# Altium schematic sheet Boot Mode Selection & JTAG.SchDoc
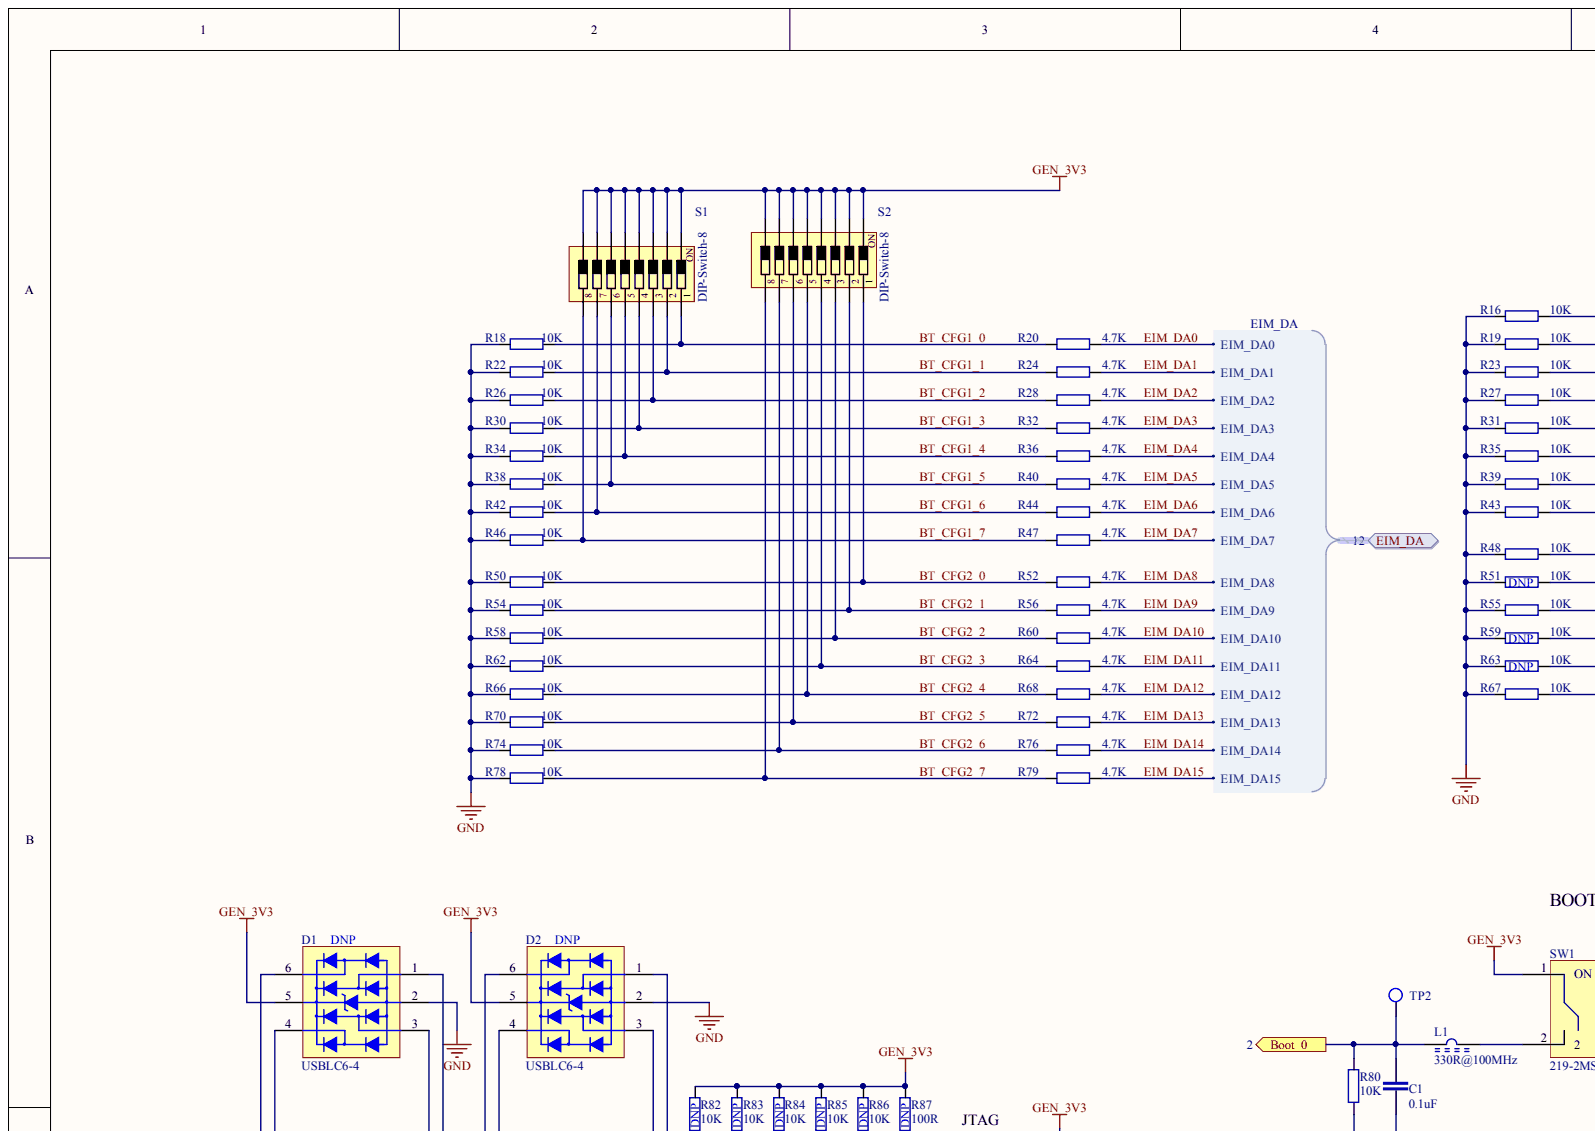
[diagram p0: Boot Mode Selection & JTAG.SchDoc, top left]
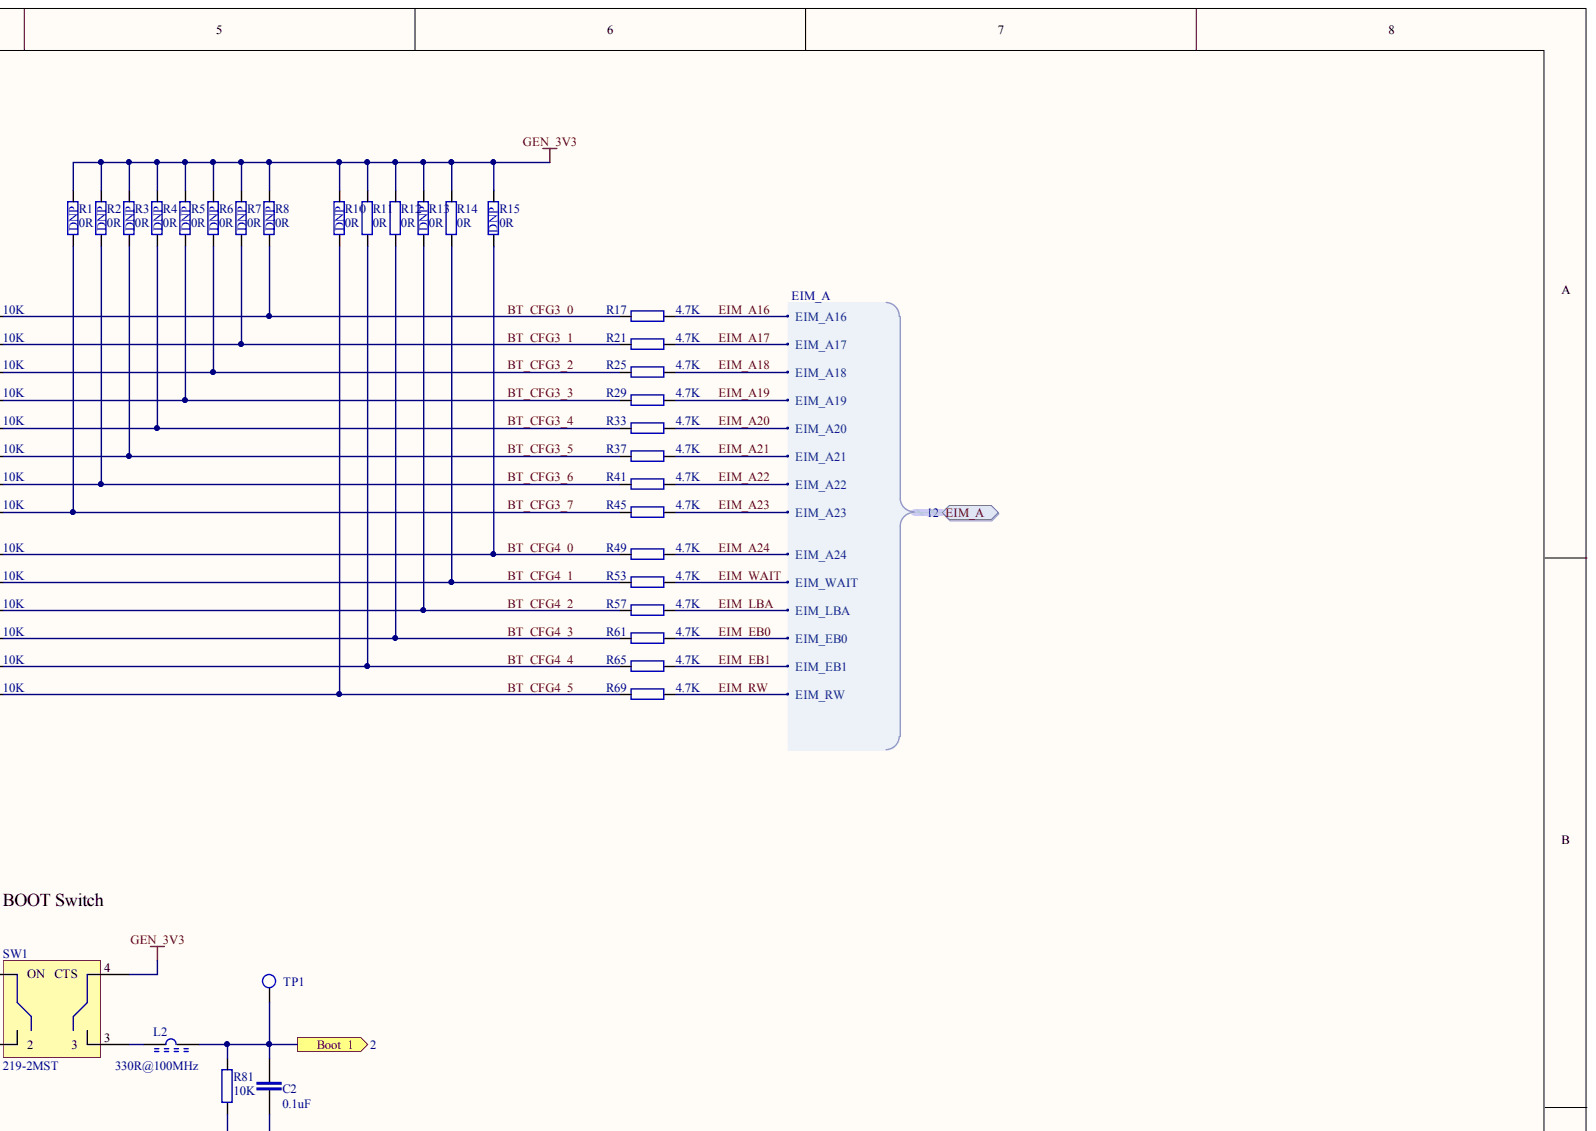
[diagram p1: Boot Mode Selection & JTAG.SchDoc, top right]
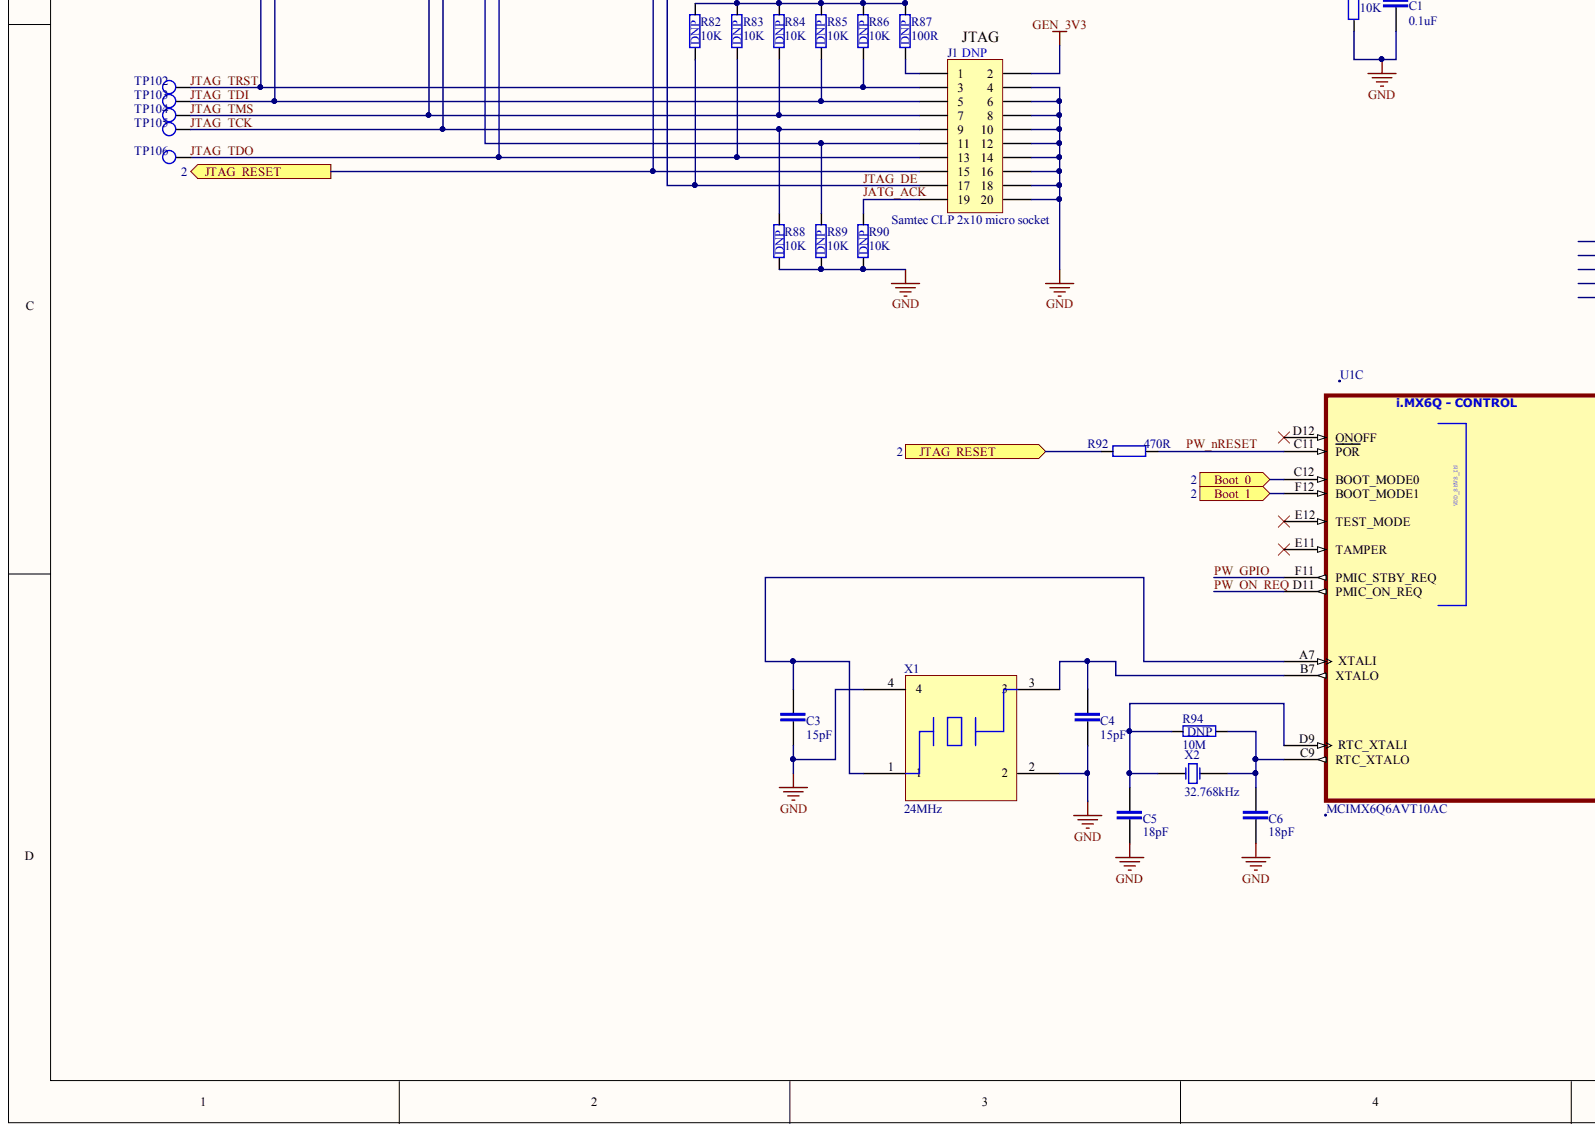
[diagram p2: Boot Mode Selection & JTAG.SchDoc, bottom left]
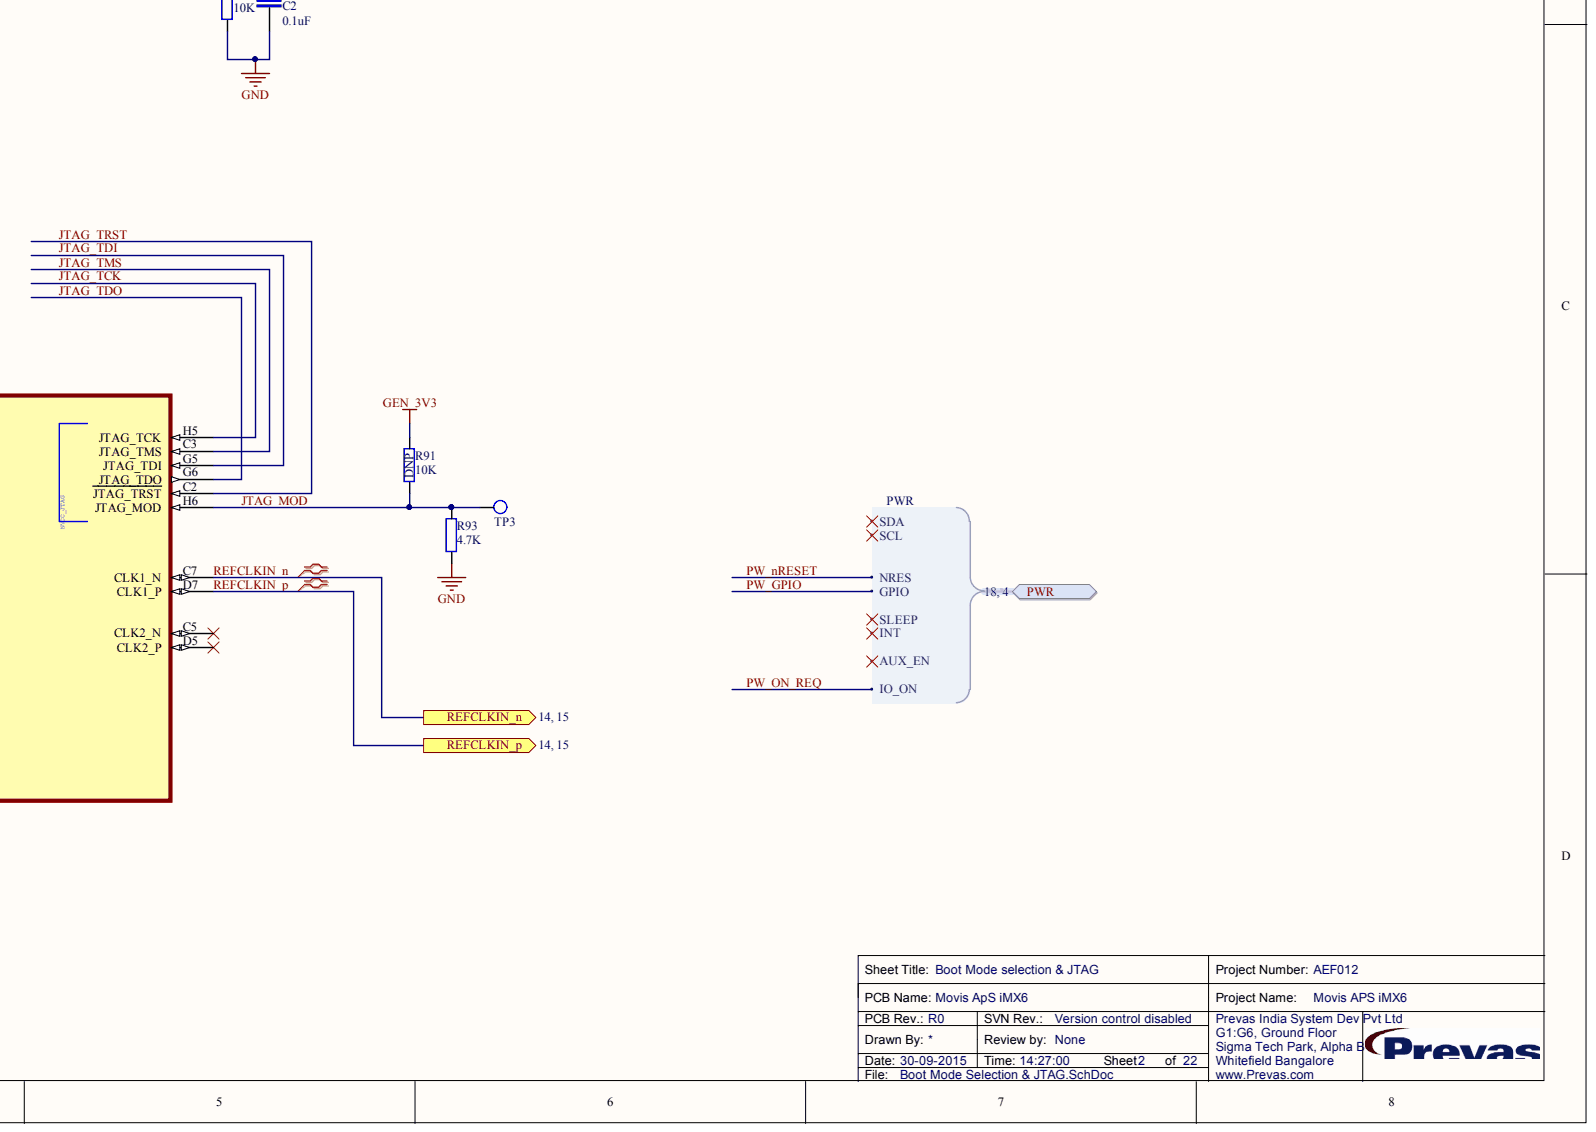
[diagram p3: Boot Mode Selection & JTAG.SchDoc, bottom right]

## Parts
C1  0.1uF  [CAPC1005X55N]
C2  0.1uF  [CAPC1005X55N]
C3  15pF  [CAPC1005X55N]
C4  15pF  [CAPC1005X55N]
C5  18pF  [CAPC1005X55N]
C6  18pF  [CAPC1005X55N]
D1  USBLC6-4  Fitted: DNP  [SOT23-6L]
D2  USBLC6-4  Fitted: DNP  [SOT23-6L]
J1  Samtec CLP 2x10 micro socket  Fitted: DNP  source_manufacturer_1: Samtec  [CLP-110-02-L-D-P(Pins Swop)]
L1  330R@100MHz  [INDC1608X95N]
L2  330R@100MHz  [INDC1608X95N]
R1  0R  Fitted: DNP  [RESC1608X55N]
R2  0R  Fitted: DNP  [RESC1608X55N]
R3  0R  Fitted: DNP  [RESC1608X55N]
R4  0R  Fitted: DNP  [RESC1608X55N]
R5  0R  Fitted: DNP  [RESC1608X55N]
R6  0R  Fitted: DNP  [RESC1608X55N]
R7  0R  Fitted: DNP  [RESC1608X55N]
R8  0R  Fitted: DNP  [RESC1608X55N]
R10  0R  Fitted: DNP  [RESC1608X55N]
R11  0R  [RESC1608X55N]
R12  0R  [RESC1608X55N]
R13  0R  Fitted: DNP  [RESC1608X55N]
R14  0R  [RESC1608X55N]
R15  0R  Fitted: DNP  [RESC1608X55N]
R16  10K  [RESC1608X55N]
R17  4.7K  [RESC1608X55N]
R18  10K  [RESC1608X55N]
R19  10K  [RESC1608X55N]
R20  4.7K  [RESC1608X55N]
R21  4.7K  [RESC1608X55N]
R22  10K  [RESC1608X55N]
R23  10K  [RESC1608X55N]
R24  4.7K  [RESC1608X55N]
R25  4.7K  [RESC1608X55N]
R26  10K  [RESC1608X55N]
R27  10K  [RESC1608X55N]
R28  4.7K  [RESC1608X55N]
R29  4.7K  [RESC1608X55N]
R30  10K  [RESC1608X55N]
R31  10K  [RESC1608X55N]
R32  4.7K  [RESC1608X55N]
R33  4.7K  [RESC1608X55N]
R34  10K  [RESC1608X55N]
R35  10K  [RESC1608X55N]
R36  4.7K  [RESC1608X55N]
R37  4.7K  [RESC1608X55N]
R38  10K  [RESC1608X55N]
R39  10K  [RESC1608X55N]
R40  4.7K  [RESC1608X55N]
R41  4.7K  [RESC1608X55N]
R42  10K  [RESC1608X55N]
R43  10K  [RESC1608X55N]
R44  4.7K  [RESC1608X55N]
R45  4.7K  [RESC1608X55N]
R46  10K  [RESC1608X55N]
R47  4.7K  [RESC1608X55N]
R48  10K  [RESC1608X55N]
R49  4.7K  [RESC1608X55N]
R50  10K  [RESC1608X55N]
R51  10K  Fitted: DNP  [RESC1608X55N]
R52  4.7K  [RESC1608X55N]
R53  4.7K  [RESC1608X55N]
R54  10K  [RESC1608X55N]
R55  10K  [RESC1608X55N]
R56  4.7K  [RESC1608X55N]
R57  4.7K  [RESC1608X55N]
R58  10K  [RESC1608X55N]
R59  10K  Fitted: DNP  [RESC1608X55N]
R60  4.7K  [RESC1608X55N]
R61  4.7K  [RESC1608X55N]
R62  10K  [RESC1608X55N]
R63  10K  Fitted: DNP  [RESC1608X55N]
R64  4.7K  [RESC1608X55N]
R65  4.7K  [RESC1608X55N]
R66  10K  [RESC1608X55N]
R67  10K  [RESC1608X55N]
R68  4.7K  [RESC1608X55N]
R69  4.7K  [RESC1608X55N]
R70  10K  [RESC1608X55N]
R72  4.7K  [RESC1608X55N]
R74  10K  [RESC1608X55N]
R76  4.7K  [RESC1608X55N]
R78  10K  [RESC1608X55N]
R79  4.7K  [RESC1608X55N]
R80  10K  [RESC1608X55N]
R81  10K  [RESC1608X55N]
R82  10K  Fitted: DNP  [RESC1608X55N]
R83  10K  Fitted: DNP  [RESC1608X55N]
R84  10K  Fitted: DNP  [RESC1608X55N]
R85  10K  Fitted: DNP  [RESC1608X55N]
R86  10K  [RESC1608X55N]
R87  100R  Fitted: DNP  [RESC1608X55N]
R88  10K  Fitted: DNP  [RESC1608X55N]
R89  10K  Fitted: DNP  [RESC1608X55N]
R90  10K  Fitted: DNP  [RESC1608X55N]
R91  10K  Fitted: DNP  [RESC1608X55N]
R92  470R  [RESC1608X55N]
R93  4.7K  [RESC1608X55N]
R94  10M  Fitted: DNP  [RESC1608X55N]
S1  DIP-Switch-8  [SW_DHN-08F-T-V]
S2  DIP-Switch-8  [SW_DHN-08F-T-V]
SW1  219-2MST  [SW_219-2MST]
TP1  Testpoint  [TP_SMD]  (value not drawn)  (no BOM)
TP2  Testpoint  [TP_SMD]  (value not drawn)  (no BOM)
TP3  Testpoint  [TP_SMD]  (value not drawn)  (no BOM)
TP102  Testpoint  [TP_SMD]  (value not drawn)  (no BOM)
TP103  Testpoint  [TP_SMD]  (value not drawn)  (no BOM)
TP104  Testpoint  [TP_SMD]  (value not drawn)  (no BOM)
TP105  Testpoint  [TP_SMD]  (value not drawn)  (no BOM)
TP106  Testpoint  [TP_SMD]  (value not drawn)  (no BOM)
U1  MCIMX6Q6AVT10AC  [BGA624C80P25X25_2100X2100X160]  (part C)
X1  24MHz  [OSCCC240X390X80-4N]
X2  32.768kHz  [OSC_AB26TRB-32.768kHz]

## Ports
BOOT_0, BOOT_1, EIM_A, EIM_A.EIM_A16, EIM_A.EIM_A17, EIM_A.EIM_A18, EIM_A.EIM_A19, EIM_A.EIM_A20, EIM_A.EIM_A21, EIM_A.EIM_A22, EIM_A.EIM_A23, EIM_A.EIM_A24, EIM_A.EIM_EB0, EIM_A.EIM_EB1, EIM_A.EIM_LBA, EIM_A.EIM_RW, EIM_A.EIM_WAIT, EIM_DA, EIM_DA.EIM_DA0, EIM_DA.EIM_DA1, EIM_DA.EIM_DA2, EIM_DA.EIM_DA3, EIM_DA.EIM_DA4, EIM_DA.EIM_DA5, EIM_DA.EIM_DA6, EIM_DA.EIM_DA7, EIM_DA.EIM_DA8, EIM_DA.EIM_DA9, EIM_DA.EIM_DA10, EIM_DA.EIM_DA11, EIM_DA.EIM_DA12, EIM_DA.EIM_DA13, EIM_DA.EIM_DA14, EIM_DA.EIM_DA15, JTAG_RESET, PWR, PWR.AUX_EN, PWR.GPIO, PWR.INT, PWR.IO_ON, PWR.NRES, PWR.SCL, PWR.SDA, PWR.SLEEP, REFCLKIN_N, REFCLKIN_P

## Nets
GEN_3V3: D1.5 D2.5 J1.2 R1.2 R2.2 R3.2 R4.2 R5.2 R6.2 R7.2 R8.2 R10.2 R11.2 R12.2 R13.2 R14.2 R15.2 R82.1 R83.1 R84.1 R85.1 R86.1 R87.2 R91.2 S1.9 S1.10 S1.11 S1.12 S1.13 S1.14 S1.15 S1.16 S2.9 S2.10 S2.11 S2.12 S2.13 S2.14 S2.15 S2.16 SW1.1(ON) SW1.4(CTS)
GND: C1.1 C2.1 C3.1 C4.1 C5.1 C6.1 D1.2 D2.2 J1.4 J1.6 J1.8 J1.10 J1.12 J1.14 J1.16 J1.18 J1.20 R16.1 R18.1 R19.1 R22.1 R23.1 R26.1 R27.1 R30.1 R31.1 R34.1 R35.1 R38.1 R39.1 R42.1 R43.1 R46.1 R48.1 R50.1 R51.1 R54.1 R55.1 R58.1 R59.1 R62.1 R63.1 R66.1 R67.1 R70.1 R74.1 R78.1 R80.2 R81.2 R88.2 R89.2 R90.2 R93.2 X1.2 X1.4
BT_CFG1_0: R18.2 R20.1 S1.1
BT_CFG1_1: R22.2 R24.1 S1.2
BT_CFG1_2: R26.2 R28.1 S1.3
BT_CFG1_3: R30.2 R32.1 S1.4
BT_CFG1_4: R34.2 R36.1 S1.5
BT_CFG1_5: R38.2 R40.1 S1.6
BT_CFG1_6: R42.2 R44.1 S1.7
BT_CFG1_7: R46.2 R47.1 S1.8
BT_CFG2_0: R50.2 R52.1 S2.1
BT_CFG2_1: R54.2 R56.1 S2.2
BT_CFG2_2: R58.2 R60.1 S2.3
BT_CFG2_3: R62.2 R64.1 S2.4
BT_CFG2_4: R66.2 R68.1 S2.5
BT_CFG2_5: R70.2 R72.1 S2.6
BT_CFG2_6: R74.2 R76.1 S2.7
BT_CFG2_7: R78.2 R79.1 S2.8
BT_CFG3_0: R8.1 R16.2 R17.1
BT_CFG3_1: R7.1 R19.2 R21.1
BT_CFG3_2: R6.1 R23.2 R25.1
BT_CFG3_3: R5.1 R27.2 R29.1
BT_CFG3_4: R4.1 R31.2 R33.1
BT_CFG3_5: R3.1 R35.2 R37.1
BT_CFG3_6: R2.1 R39.2 R41.1
BT_CFG3_7: R1.1 R43.2 R45.1
BT_CFG4_0: R15.1 R48.2 R49.1
BT_CFG4_1: R14.1 R51.2 R53.1
BT_CFG4_2: R13.1 R55.2 R57.1
BT_CFG4_3: R12.1 R59.2 R61.1
BT_CFG4_4: R11.1 R63.2 R65.1
BT_CFG4_5: R10.1 R67.2 R69.1
EIM_A16: R17.2 ; port EIM_A
EIM_A17: R21.2 ; port EIM_A
EIM_A18: R25.2 ; port EIM_A
EIM_A19: R29.2 ; port EIM_A
EIM_A20: R33.2 ; port EIM_A
EIM_A21: R37.2 ; port EIM_A
EIM_A22: R41.2 ; port EIM_A
EIM_A23: R45.2 ; port EIM_A
EIM_A24: R49.2 ; port EIM_A
EIM_DA0: R20.2 ; port EIM_DA
EIM_DA1: R24.2 ; port EIM_DA
EIM_DA2: R28.2 ; port EIM_DA
EIM_DA3: R32.2 ; port EIM_DA
EIM_DA4: R36.2 ; port EIM_DA
EIM_DA5: R40.2 ; port EIM_DA
EIM_DA6: R44.2 ; port EIM_DA
EIM_DA7: R47.2 ; port EIM_DA
EIM_DA8: R52.2 ; port EIM_DA
EIM_DA9: R56.2 ; port EIM_DA
EIM_DA10: R60.2 ; port EIM_DA
EIM_DA11: R64.2 ; port EIM_DA
EIM_DA12: R68.2 ; port EIM_DA
EIM_DA13: R72.2 ; port EIM_DA
EIM_DA14: R76.2 ; port EIM_DA
EIM_DA15: R79.2 ; port EIM_DA
EIM_EB0: R61.2 ; port EIM_A
EIM_EB1: R65.2 ; port EIM_A
EIM_LBA: R57.2 ; port EIM_A
EIM_RW: R69.2 ; port EIM_A
EIM_WAIT: R53.2 ; port EIM_A
JATG_ACK: J1.19 R90.1
JTAG_DE: D2.1 J1.17 R82.2
JTAG_MOD: R91.1 R93.1 TP3.1 U1.H6(JTAG_MOD)
JTAG_RESET: D2.3 J1.15 R92.1 ; port JTAG_RESET
JTAG_TCK: D1.1 J1.9 R88.1 TP105.1 U1.H5(JTAG_TCK)
JTAG_TDI: D1.4 J1.5 R85.2 TP103.1 U1.G5(JTAG_TDI)
JTAG_TDO: D2.4 J1.13 R83.2 TP106.1 U1.G6(JTAG_TDO)
JTAG_TMS: D1.3 J1.7 R84.2 TP104.1 U1.C3(JTAG_TMS)
JTAG_TRST: D1.6 J1.3 R86.2 TP102.1 U1.C2(JTAG_TRST)
PW_GPIO: U1.F11(PMIC_STBY_REQ) ; port PWR
PW_NRESET: R92.2 U1.C11(POR) ; port PWR
PW_ON_REQ: U1.D11(PMIC_ON_REQ) ; port PWR
REFCLKIN_N: U1.C7(CLK1_N) ; port REFCLKIN_N
REFCLKIN_P: U1.D7(CLK1_P) ; port REFCLKIN_P
NetC1_2: C1.2 L1.1 R80.1 TP2.1 U1.C12(BOOT_MODE0) ; port BOOT_0
NetC2_2: C2.2 L2.2 R81.1 TP1.1 U1.F12(BOOT_MODE1) ; port BOOT_1
NetC3_2: C3.2 U1.A7(XTALI) X1.1
NetC4_2: C4.2 U1.B7(XTALO) X1.3
NetC5_2: C5.2 R94.1 U1.D9(RTC_XTALI) X2.1
NetC6_2: C6.2 R94.2 U1.C9(RTC_XTALO) X2.2
NetD2_6: D2.6 J1.11 R89.1
NetJ1_1: J1.1 R87.1
NetL1_2: L1.2 SW1.2
NetL2_1: L2.1 SW1.3
N00071: ; port PWR
N00072: ; port PWR
N00073: ; port PWR
N00074: ; port PWR
N00075: ; port PWR

Not connected: U1 C5(CLK2_N) D5(CLK2_P) D12(ONOFF) E11(TAMPER) E12(TEST_MODE)

## Library data of the parts
C1 C2 = INNISI-73: CAP CER 0.1UF 50V 10% X5R 0402; ref_part_type: /capacitor/non_polarized/ceramic/; source_manufacturer_1: TDK Corporation; source_manufacturer_1_pn: C1005X5R1H104K050BB; cae_altium_pcb_status: Prototype; Database Table Name: altium_default; db_rev: 7569; elec_temp_range: -55 to +85 C; phy_height: 0.55mm; phy_mounting: SMD; phy_packaging_type: 0402; ref_datasheet: INNISI-71; cae_altium_sch_status: Prototype; Component Kind: Standard; ComponentLink1Description: Datasheet; ComponentLink1URL: https://cop201.prevas.dk/viewvc/datasheet/INNISI-71.pdf?root=hw_components&view=co; db_created: 2015-08-03 03:34:59.0; db_last_updated: 03-08-2015 03:35:01; db_local_id: INNISI-73; db_status: Prototype; elec_tolerance: ±10%; elec_value: 0.1uF; elec_voltage_rating: 50V; phy_material: X5R; ref_keyword: CAP CER 0.1UF 50V 10% X5R 0402; Library Name: altium_mysql.dblib
C3 C4 = INNISI-74: CAP CER 15PF 50V 5% NPO 0402; ref_part_type: /capacitor/non_polarized/ceramic/; source_manufacturer_1: Yageo; source_manufacturer_1_pn: CC0402JRNPO9BN150; cae_altium_pcb_status: Prototype; Database Table Name: altium_default; db_rev: 7570; elec_temp_range: -55 to +125C; phy_height: 0.55mm; phy_material: C0G; phy_mounting: SMD; phy_packaging_type: 0402; ref_datasheet: INNISI-72; ref_keyword: Multilayer chip capacitor; cae_altium_sch_status: Prototype; Component Kind: Standard; ComponentLink1Description: Datasheet; ComponentLink1URL: https://cop201.prevas.dk/viewvc/datasheet/INNISI-72.pdf?root=hw_components&view=co; db_created: 2015-08-03 03:48:01.0; db_last_updated: 03-08-2015 03:48:03; db_local_id: INNISI-74; db_status: Prototype; elec_tolerance: 5%; elec_value: 15pF; elec_voltage_rating: 50V; Library Name: altium_mysql.dblib
C5 C6 = INNISI-75: CAP CER 18PF 50V 5% NPO 0402; ref_part_type: /capacitor/non_polarized/ceramic/; source_manufacturer_1: Yageo; source_manufacturer_1_pn: CC0402JRNPO9BN180; cae_altium_pcb_status: Prototype; Database Table Name: altium_default; db_rev: 7571; elec_temp_range: -55 to +125C; phy_height: 0.55mm; phy_material: C0G; phy_mounting: SMD; phy_packaging_type: 0402; ref_datasheet: INNISI-71; ref_keyword: Ceramic Capacitor 0402; cae_altium_sch_status: Prototype; Component Kind: Standard; ComponentLink1Description: Datasheet; ComponentLink1URL: https://cop201.prevas.dk/viewvc/datasheet/INNISI-71.pdf?root=hw_components&view=co; db_created: 2015-08-03 03:51:37.0; db_last_updated: 03-08-2015 03:51:38; db_local_id: INNISI-75; db_status: Prototype; elec_tolerance: 5%; elec_value: 18pF; elec_voltage_rating: 50V; Library Name: altium_mysql.dblib
D1 D2 = NIMA-155: Dual very low capacitance ESD protection for USB 2.0 up to 480Mb/s; ref_part_type: /diode/transient/; source_manufacturer_1: STMicroelectronics; cae_altium_pcb_status: Prototype; Database Table Name: altium_default; db_rev: 1817; elec_temp_range: -40 to 125; elec_voltage_rating: 5V; phy_height: 1.45mm; phy_mounting: SMD; ref_datasheet: NIMA-115; cae_altium_sch_status: Prototype; Component Kind: Standard; ComponentLink1Description: Datasheet; ComponentLink1URL: https://cop201.prevas.dk/viewvc/datasheet/NIMA-115.pdf?root=hw_components&view=co; db_created: 2009-09-25 21:20:59; db_last_updated: 25-09-2009 21:21:20; db_local_id: NIMA-155; db_status: Prototype; elec_value: USBLC6-4; Mounted: Yes; phy_packaging_type: SOT23-6L; ref_keyword: ESD; source_manufacturer_1_pn: USBLC6-4; Library Name: altium_mysql.dblib
J1 = GEPW-55: ref_part_type: /connector/20 pins/; source_manufacturer_1_pn: CLP-110-02-L-D-P; Database Table Name: altium_default; db_rev: 0; db_status: Prototype; elec_current_rating: 1,75A; elec_temp_range: -55 to 125; phy_height: 2.26mm; phy_mounting: SMD; ref_datasheet: GEPW-43; ref_description: Samtec CLP low profile socket, board-to-board, 50mil pitch, 2x10 pin socket (pins swop); ref_keyword: Samtec board-to-board, CLP; Component Kind: Standard; ComponentLink1Description: Datasheet; ComponentLink1URL: https://cop201/viewvc/datasheet/GEPW-43.pdf?root=hw_components&view=co; db_created: 2009-01-21 14:17:10; db_last_updated: 21-01-2009 14:36:13; db_local_id: GEPW-55; elec_value: Samtec CLP 2x10 micro socket; Library Name: altium_mysql.dblib
L1 L2 = TELA-164: Chip EMIFIL Inductor Type, 330R@100MHz, 0.15R@0Hz; ref_part_type: /inductor/lossy/; source_manufacturer_1: Murata; source_manufacturer_1_pn: BLM18PG331SN1*; cae_altium_pcb_status: Prototype; Database Table Name: altium_default; db_rev: 5543; elec_current_rating: 1.2A; elec_frequency_rating: 100MHz; elec_temp_range: -55 to 125; elec_tolerance: 25%; phy_height: 0.95mm; phy_material: Ferrite; phy_mounting: SMD; phy_packaging_type: 0603; ref_datasheet: SOMO-49; ref_keyword: Ferrite bead 0603; cae_altium_sch_status: Prototype; Component Kind: Standard; ComponentLink1Description: Datasheet; ComponentLink1URL: https://cop201.prevas.dk/viewvc/datasheet/SOMO-49.pdf?root=hw_components&view=co; db_created: 2013-03-14 15:35:31.0; db_last_updated: 14-03-2013 16:11:58; db_local_id: TELA-164; db_status: Prototype; elec_value: 330R@100MHz; Library Name: altium_mysql.dblib
R1-R8 R10-R15 = INVIHA-4: RES SMD 0.0 OHM JUMPER 1/10W; ref_part_type: /resistor/fixed/; source_manufacturer_1: Panasonic Electronic Components; source_manufacturer_1_pn: ERJ-3GEY0R00V; cae_altium_pcb_status: Prototype; Database Table Name: altium_default; db_rev: 7580; elec_power_rating: 0.1W; elec_temp_range: -55 to +155° C; phy_height: 0.55mm; phy_packaging_type: 0603; ref_datasheet: INVIHA-3; ref_keyword: Chip Resistor; cae_altium_sch_status: Prototype; Component Kind: Standard; ComponentLink1Description: Datasheet; ComponentLink1URL: https://cop201.prevas.dk/viewvc/datasheet/INVIHA-3.pdf?root=hw_components&view=co; db_created: 2015-08-03 05:59:19.0; db_last_updated: 03-08-2015 5:59:21 AM; db_local_id: INVIHA-4; db_status: Prototype; elec_value: 0R; phy_mounting: SMD; Library Name: altium_mysql.dblib
R16 R18 R19 R22 R23 R26 R27 R30 R31 R34 R35 R38 R39 R42 R43 R46 R48 R50 R51 R54 R55 R58 R59 R62 R63 R66 R67 R70 R74 R78 R80-R86 R88-R91 = INVIHA-3: RES SMD 10K OHM 1% 1/10W 0603; ref_part_type: /resistor/fixed/; source_manufacturer_1: Yageo; source_manufacturer_1_pn: RC0603FR-0710KL; cae_altium_pcb_status: Prototype; Database Table Name: altium_default; db_rev: 7575; elec_power_rating: 0.1W; elec_temp_range: -55 to +155° C; phy_height: 0.55mm; phy_packaging_type: 0603; ref_datasheet: INVIHA-2; ref_keyword: Chip Resistor; cae_altium_sch_status: Prototype; Component Kind: Standard; ComponentLink1Description: Datasheet; ComponentLink1URL: https://cop201.prevas.dk/viewvc/datasheet/INVIHA-2.pdf?root=hw_components&view=co; db_created: 2015-08-03 05:39:03.0; db_last_updated: 03-08-2015 5:39:05 AM; db_local_id: INVIHA-3; db_status: Prototype; elec_tolerance: 1%; elec_value: 10K; phy_mounting: SMD; Library Name: altium_mysql.dblib
  R80-R86 R88-R90: Mounted: Yes
R17 R20 R21 R24 R25 R28 R29 R32 R33 R36 R37 R40 R41 R44 R45 R47 R49 R52 R53 R56 R57 R60 R61 R64 R65 R68 R69 R72 R76 R79 R93 = INVIHA-5: RES SMD 4.7K OHM 1% 1/10W 0603; ref_part_type: /resistor/fixed/; source_manufacturer_1: Yageo; source_manufacturer_1_pn: RC0603FR-074K7L; cae_altium_pcb_status: Prototype; Database Table Name: altium_default; db_rev: 7581; elec_power_rating: 0.1W; elec_temp_range: -55 to +155° C; phy_height: 0.55mm; phy_packaging_type: 0603; ref_datasheet: INVIHA-4; ref_keyword: Chip Resistor; cae_altium_sch_status: Prototype; Component Kind: Standard; ComponentLink1Description: Datasheet; ComponentLink1URL: https://cop201.prevas.dk/viewvc/datasheet/INVIHA-4.pdf?root=hw_components&view=co; db_created: 2015-08-03 06:09:48.0; db_last_updated: 03-08-2015 6:09:49 AM; db_local_id: INVIHA-5; db_status: Prototype; elec_tolerance: 1%; elec_value: 4.7K; phy_mounting: SMD; Library Name: altium_mysql.dblib
R87 = INVIHA-6: RES SMD 100 OHM 1% 1/10W 0603; ref_part_type: /resistor/fixed/; source_manufacturer_1: Yageo; source_manufacturer_1_pn: RC0603FR-07100RL; cae_altium_pcb_status: Prototype; Database Table Name: altium_default; db_rev: 7583; elec_power_rating: 0.1W; elec_temp_range: -55 to +155° C; phy_height: 0.55mm; phy_packaging_type: 0603; ref_datasheet: INVIHA-2; ref_keyword: Chip Resistor; cae_altium_sch_status: Prototype; Component Kind: Standard; ComponentLink1Description: Datasheet; ComponentLink1URL: https://cop201.prevas.dk/viewvc/datasheet/INVIHA-2.pdf?root=hw_components&view=co; db_created: 2015-08-03 06:19:06.0; db_last_updated: 03-08-2015 6:19:07 AM; db_local_id: INVIHA-6; db_status: Prototype; elec_tolerance: 1%; elec_value: 100R; Mounted: Yes; phy_mounting: SMD; Library Name: altium_mysql.dblib
R92 = INVIHA-7: RES SMD 470 OHM 1% 1/10W 0603; ref_part_type: /resistor/fixed/; source_manufacturer_1: Yageo; source_manufacturer_1_pn: RC0603FR-07470RL; cae_altium_pcb_status: Prototype; Database Table Name: altium_default; db_rev: 7584; elec_power_rating: 0.1W; elec_temp_range: -55 to +155° C; phy_height: 0.55mm; phy_packaging_type: 0603; ref_datasheet: INVIHA-2; ref_keyword: Chip Resistor; cae_altium_sch_status: Prototype; Component Kind: Standard; ComponentLink1Description: Datasheet; ComponentLink1URL: https://cop201.prevas.dk/viewvc/datasheet/INVIHA-2.pdf?root=hw_components&view=co; db_created: 2015-08-03 06:24:05.0; db_last_updated: 03-08-2015 6:24:06 AM; db_local_id: INVIHA-7; db_status: Prototype; elec_tolerance: 1%; elec_value: 470R; phy_mounting: SMD; Library Name: altium_mysql.dblib
R94 = INVIHA-48: RES SMD 10M OHM 5% 1/10W 0603; ref_part_type: /resistor/fixed/; source_manufacturer_1: Yageo; source_manufacturer_1_pn: RC0603JR-0710ML; cae_altium_pcb_status: Prototype; Database Table Name: altium_default; db_rev: 7653; elec_power_rating: 0.1W; elec_temp_range: -55 to +155° C; phy_height: 0.55mm; phy_packaging_type: 0603; ref_datasheet: INVIHA-2; ref_keyword: Chip Resistor; cae_altium_sch_status: Prototype; Component Kind: Standard; ComponentLink1Description: Datasheet; ComponentLink1URL: https://cop201.prevas.dk/viewvc/datasheet/INVIHA-2.pdf?root=hw_components&view=co; db_created: 2015-08-04 04:48:09.0; db_last_updated: 04-08-2015 4:48:11 AM; db_local_id: INVIHA-48; db_status: Prototype; elec_tolerance: 5%; elec_value: 10M; phy_mounting: SMD; Library Name: altium_mysql.dblib
S1 S2 = NIMA-75: 8 dip switch; ref_part_type: /elmech/transducer/switch/; source_manufacturer_1: Diptronics; source_manufacturer_1_pn: DHN-08F-T-V; cae_altium_pcb_status: Prototype; Database Table Name: altium_default; db_rev: 1369; elec_current_rating: 100mA; elec_temp_range: -40 to 85; elec_voltage_rating: 50VDC; phy_height: 1.70mm; phy_mounting: SMD; ref_datasheet: NIMA-59; cae_altium_sch_status: Prototype; Component Kind: Standard; ComponentLink1Description: Datasheet; ComponentLink1URL: https://cop201.prevas.dk/viewvc/datasheet/NIMA-59.pdf?root=hw_components&view=co; db_created: 2009-05-01 16:02:00; db_last_updated: 02-06-2009 16:08:45; db_local_id: NIMA-75; db_status: Prototype; elec_value: DIP-Switch-8; phy_packaging_type: DIP; ref_keyword: dip switch; Library Name: altium_mysql.dblib
SW1 = INRAAN-223: DIP Switches / SIP Switches SPST 2 switch sections; ref_part_type: /elmech/transducer/switch/; source_manufacturer_1: CTS Electronic Components; cae_altium_pcb_status: Prototype; Database Table Name: altium_default; db_rev: 6925; elec_current_rating: 100mA; elec_temp_range: - 55 C to + 85 C; phy_height: 3.8mm; phy_mounting: SMD; ref_datasheet: INRAAN-208; cae_altium_sch_status: Prototype; Component Kind: Standard; ComponentLink1Description: Datasheet; ComponentLink1URL: https://cop201.prevas.dk/viewvc/datasheet/INRAAN-208.pdf?root=hw_components&view=co; db_created: 2014-12-01 06:07:17.0; db_last_updated: 01-12-2014 06:07:18; db_local_id: INRAAN-223; db_status: Prototype; elec_value: 219-2MST; ref_keyword: Switch; source_manufacturer_1_pn: 219-2MST; Library Name: altium_mysql.dblib
TP1-TP3 TP102-TP106 = JEPE-114: Test Point SMD; ref_part_type: /pcb_item/; cae_altium_pcb_status: Prototype; Database Table Name: altium_default; db_rev: 3281; cae_altium_sch_status: Prototype; ComponentLink1Description: Datasheet; db_created: 2008-08-18 11:39:42; db_last_updated: 23-03-2011 14:14:06; db_local_id: JEPE-114; db_status: Prototype; elec_value: Test Point; phy_mounting: SMD; ref_keyword: Test  Point; Library Name: altium_mysql.dblib
U1 = INRAAN-5: i.MX 6Dual/6Quad; ref_part_type: /integrated/digital/processor/general/; AGILE_PN: 344-01218; CAD_APPROVED: Yes; cae_altium_pcb_status: Prototype; CLASS: IC; Database Table Name: altium_default; db_rev: 6247; elec_temp_range: -40 to +105; elec_voltage_rating: 1.5V; MFG_NAME01: FREESCALE SEMICONDUCTOR; phy_height: 1.85; phy_mounting: SMD; phy_packaging_type: FCPBGA96_624; ref_datasheet: INRAAN-6; cae_altium_sch_status: Prototype; Component Kind: Standard; ComponentLink1Description: Datasheet; ComponentLink1URL: https://cop201.prevas.dk/viewvc/datasheet/INRAAN-6.pdf?root=hw_components&view=co; db_created: 2013-10-21 12:25:57.0; db_last_updated: 27-12-2013 09:32:57; db_local_id: INRAAN-5; db_status: Prototype; elec_value: MCIMX6Q6AVT10AC; HEIGHT: 2.16MM; MFG_PN01: MCIMX6Q6AVT10AC; ref_keyword: i.MX 6Dual/6Quad; ROHS: Yes; source_manufacturer_1: Freescale Semiconductor; source_manufacturer_1_pn: MCIMX6Q6AVT10AC; Library Name: altium_mysql.dblib
X1 = INRAAN-222: Crystals 24MHz 10ppm BlueTooth / Zigbee; ref_part_type: /oscillator/crystal/; source_manufacturer_1: ECS; source_manufacturer_1_pn: ECS-240-13-42-CKM-TR; cae_altium_pcb_status: Prototype; Database Table Name: altium_default; db_rev: 6924; elec_power_rating: 300uW; elec_temp_range: -10C to 70C; phy_height: 0.8mm; phy_mounting: SMD; ref_datasheet: INRAAN-207; cae_altium_sch_status: Prototype; Component Kind: Standard; ComponentLink1Description: Datasheet; ComponentLink1URL: https://cop201.prevas.dk/viewvc/datasheet/INRAAN-207.pdf?root=hw_components&view=co; db_created: 2014-12-01 05:51:05.0; db_last_updated: 01-12-2014 05:55:02; db_local_id: INRAAN-222; db_status: Prototype; elec_frequency_rating: 24MHz; elec_value: 24MHz; ref_keyword: Crystals 24MHz 10ppm BlueTooth / Zigbee; Library Name: altium_mysql.dblib
X2 = MITO-92: Tuning Fork Surface Mount Crystal Oscillator; ref_part_type: /oscillator/crystal/; source_manufacturer_1: Abracon Corporation; source_manufacturer_1_pn: AB26TRB-32.768kHz; cae_altium_pcb_status: Prototype; Database Table Name: altium_default; db_rev: 4720; elec_power_rating: 1uW (max); elec_temp_range: -40°C to +85°C; elec_tolerance: ±20ppm; phy_height: 2.0mm; phy_mounting: SMD; phy_packaging_type: Cylinder; ref_datasheet: MITO-66; cae_altium_sch_status: Prototype; Component Kind: Standard; ComponentLink1Description: Datasheet; ComponentLink1URL: https://cop201.prevas.dk/viewvc/datasheet/MITO-66.pdf?root=hw_components&view=co; db_created: 2009-10-06 14:31:38; db_last_updated: 21-06-2012 15:07:32; db_local_id: MITO-92; db_status: Prototype; elec_frequency_rating: 32.768kHz; elec_value: 32.768kHz; ref_keyword: Crystal Oscillator; Library Name: altium_mysql.dblib
